# Altium schematic sheet 02_Can.SchDoc
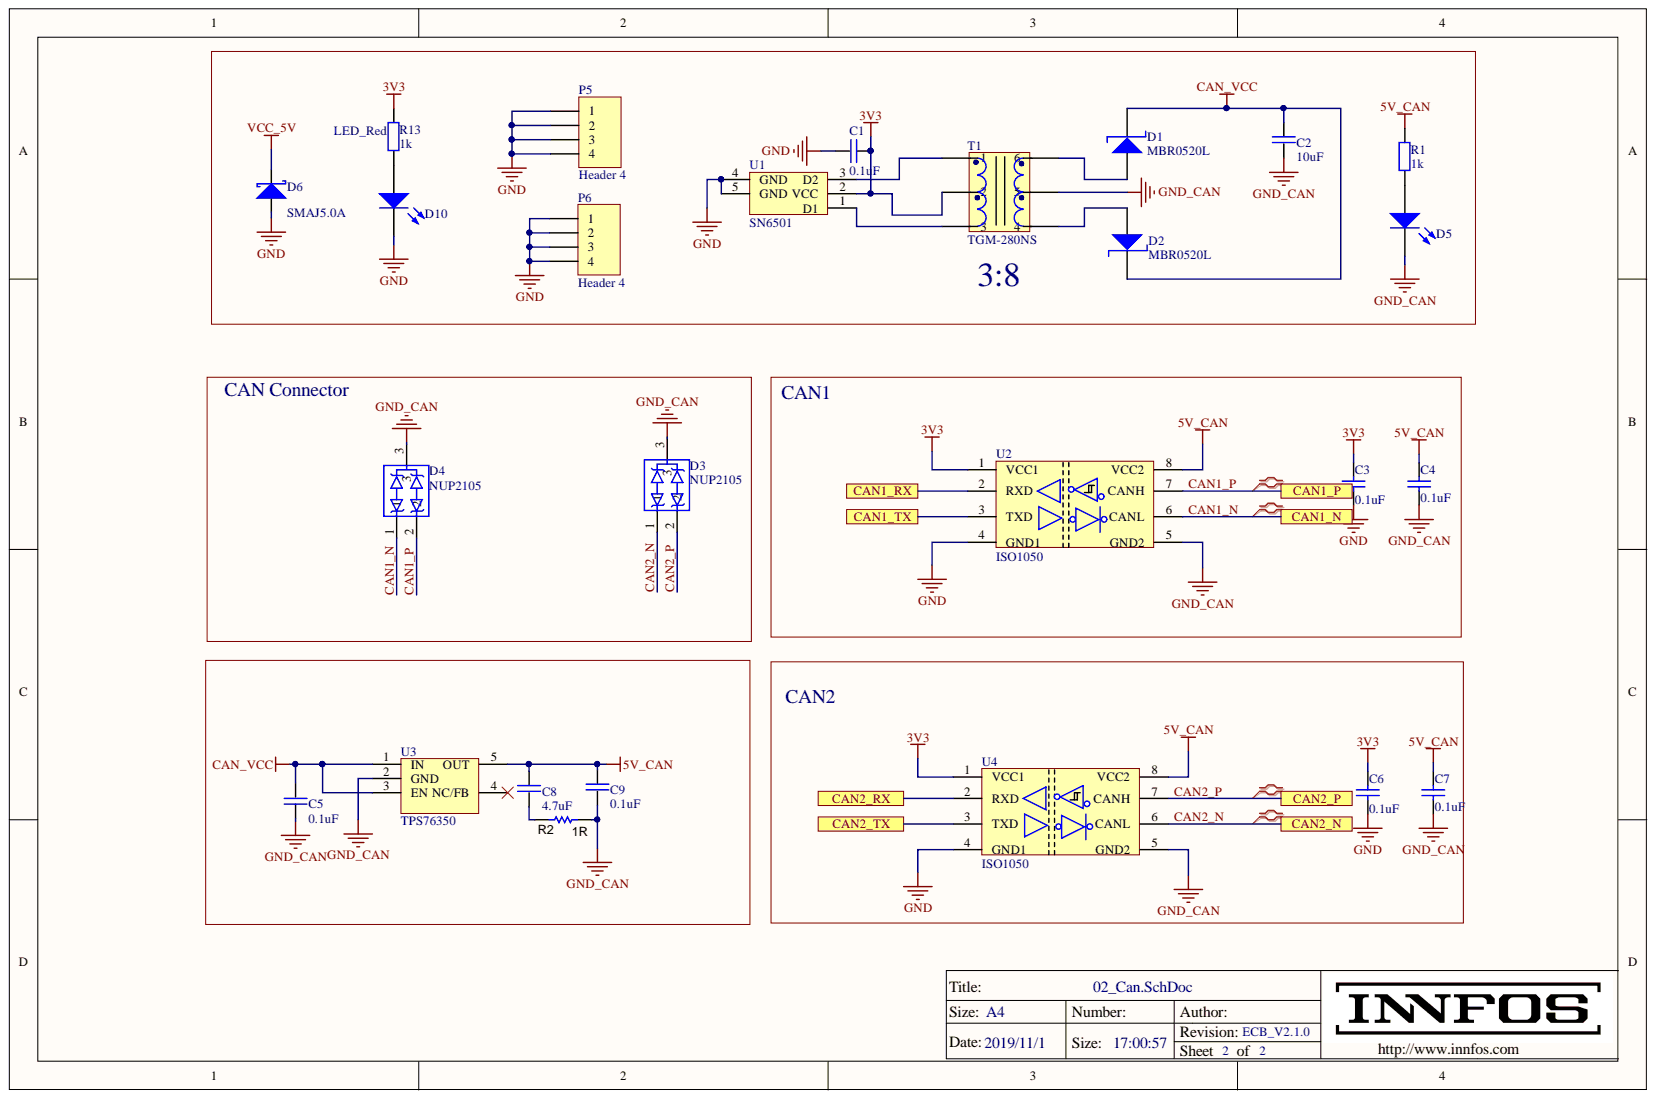
[diagram p0: 02_Can.SchDoc]

## Parts
C1  0.1uF  [0402]
C2  10uF  [0402]
C3  0.1uF  [0402]
C4  0.1uF  [0402]
C5  0.1uF  [0402]
C6  0.1uF  [0402]
C7  0.1uF  [0402]
C8  4.7uF  [0402]
C9  0.1uF  [0402]
D1  MBR0520L  [SOD123]
D2  MBR0520L  [SOD123]
D3  NUP2105  [SOT23_3]
D4  NUP2105  [SOT23_3]
D5  LED_RED  [LED_0603_RED]  (value not drawn)
D6  SMAJ5.0A  [SMA_DO_214AC]
D10  LED_Red  [LED_0603_RED]
P5  Header 4  [HDR1X4]
P6  Header 4  [HDR1X4]
R1  1k  [0402]
R2  1R  [0402]
R13  1k  [0402]
T1  TGM-280NS  [Transformer]
U1  SN6501  [SOT23_5]
U2  ISO1050  [SOP_4_85]
U3  TPS76350  [SOT23_5]
U4  ISO1050  [SOP_4_85]

## Ports
CAN1_N, CAN1_P, CAN1_RX, CAN1_TX, CAN2_N, CAN2_P, CAN2_RX, CAN2_TX

## Nets
3V3: C1.1 C3.1 C6.1 R13.2 T1.2 U1.2(VCC) U2.1(VCC1) U4.1(VCC1)
5V_CAN: C4.1 C7.1 C8.1 C9.1 R1.2 U2.8(VCC2) U3.5(OUT) U4.8(VCC2)
CAN_VCC: C2.1 C5.2 D1.1 D2.1 U3.1(IN) U3.3(EN)
GND: C1.2 C3.2 C6.2 D6.1 D10.2 P5.1 P5.2 P5.3 P5.4 P6.1 P6.2 P6.3 P6.4 U1.4(GND) U1.5(GND) U2.4(GND1) U4.4(GND1)
GND_CAN: C2.2 C4.2 C5.1 C7.2 C9.2 D3.3 D4.3 D5.2 R2.2 T1.5 U2.5(GND2) U3.2(GND) U4.5(GND2)
VCC_5V: D6.2
CAN1_N: D4.1 U2.6(CANL) ; port CAN1_N
CAN1_P: D4.2 U2.7(CANH) ; port CAN1_P
CAN1_RX: U2.2(RXD) ; port CAN1_RX
CAN1_TX: U2.3(TXD) ; port CAN1_TX
CAN2_N: D3.1 U4.6(CANL) ; port CAN2_N
CAN2_P: D3.2 U4.7(CANH) ; port CAN2_P
CAN2_RX: U4.2(RXD) ; port CAN2_RX
CAN2_TX: U4.3(TXD) ; port CAN2_TX
NetC8_2: C8.2 R2.1
NetD1_2: D1.2 T1.6
NetD2_2: D2.2 T1.4
NetD5_1: D5.1 R1.1
NetD10_1: D10.1 R13.1
NetT1_1: T1.1 U1.3(D2)
NetT1_3: T1.3 U1.1(D1)

Not connected: U3 4(NC/FB)

## Library data of the parts
C1 C5 C9 = Cap: Capacitor; Component Kind: Standard; LatestRevisionDate: 17-Jul-2002; LatestRevisionNote: Re-released for DXP Platform.; PackageDescription: Radial Cap, Thru-Hole; 2 Leads; 0.3 in Pin Spacing; PackageReference: RAD-0.3; Published: 8-Jun-2000; Value: 0.1uF; Library Name: Bettry_monitor.SCHLIB; Publisher: Altium Limited
C2 C8 = Cap: Capacitor; Value: 0.1uF; Component Kind: Standard; LatestRevisionDate: 17-Jul-2002; LatestRevisionNote: Re-released for DXP Platform.; PackageDescription: Radial Cap, Thru-Hole; 2 Leads; 0.3 in Pin Spacing; PackageReference: RAD-0.3; Published: 8-Jun-2000; Library Name: Bettry_monitor.SCHLIB; Publisher: Altium Limited
C3 C4 C6 C7 = Cap: Capacitor; Manufacturer: Generic Components; Class: Passive; Component Kind: Standard; Published: 08/06/2000; SubClass: Capacitor; Value: 0.1uF; Library Name: lclprint.SCHLIB; Publisher: Altium Hobart Technology Centre
D3 D4 = PSM712: Component Kind: Standard; Library Name: My_Schlib.SchLib
D5 D10 = LED(0603): Component Kind: Standard; Library Name: MY_INTEGRATED_LIBRARY.IntLib
D6 = Diode BAT17: Component Kind: Standard; Library Name: Miscellaneous Devices.IntLib
P5 P6 = Header 4: Header, 4-Pin; Component Kind: Standard; LatestRevisionDate: 17-Jul-2002; LatestRevisionNote: Re-released for DXP Platform.; Library Name: Miscellaneous Connectors.IntLib; Publisher: Altium Limited
R1 R13 = Res2: Resistor; Manufacturer: Generic Components; Class: Passive; Value: 0R; Component Kind: Standard; Library Name: Miscellaneous Devices.IntLib; Published: 08/06/2000; SubClass: Resistor; Publisher: Altium Hobart Technology Centre
R2 = CRCW06031K00FKEA: RES, 1.00k ohm, 1%, 0.1W, 0603; Manufacturer: Vishay-Dale; CompType: Resistor; EIA_Code: E96; Power_W: 0.1; Res_ohm: 1000; TempCo: 100 ppm/C; Tolerance_%: 1; U/M: EA; Value_Code: 1k00; VDC_V: 75; Component Kind: Standard; ComponentLink1Description: Datasheet link; ComponentLink1URL: http://www.vishay.com/docs/20035/dcrcwe3.pdf; LatestRevisionDate: 2013-01-08; Library Name: Resistor_0603.IntLib; MountType: SMT; PackageReference: 0603; PartNumber: CRCW06031K00FKEA; Published: 2008-06-26; RoHS: Y; Supplier 1: Digi-Key; Supplier 2: Mouser; Supplier 3: Farnell; Supplier Part Number 1: 541-1.00KHCT-ND; Supplier Part Number 2: 71-CRCW0603-1.0K-E3; Supplier Part Number 3: 1469740; SymbolVersion: 2009-05-29; Technology: Thick Film; Value: 1.00k; Value1: 1%; Value2: 0.1W; Publisher: Texas Instruments
T1 = Transformer: Component Kind: Standard; Library Name: My_Schlib.SchLib
D1 D2 = MBR0520L: Component Kind: Standard; Library Name: My_Schlib.SchLib
U1 = SN6501: Component Kind: Standard; Library Name: My_Schlib.SchLib
U2 U4 = ISO1050: Component Kind: Standard; Library Name: My_Schlib.SchLib
U3 = TPS76350: Component Kind: Standard; Library Name: My_Schlib.SchLib
